# Altium schematic sheet MCU.SchDoc
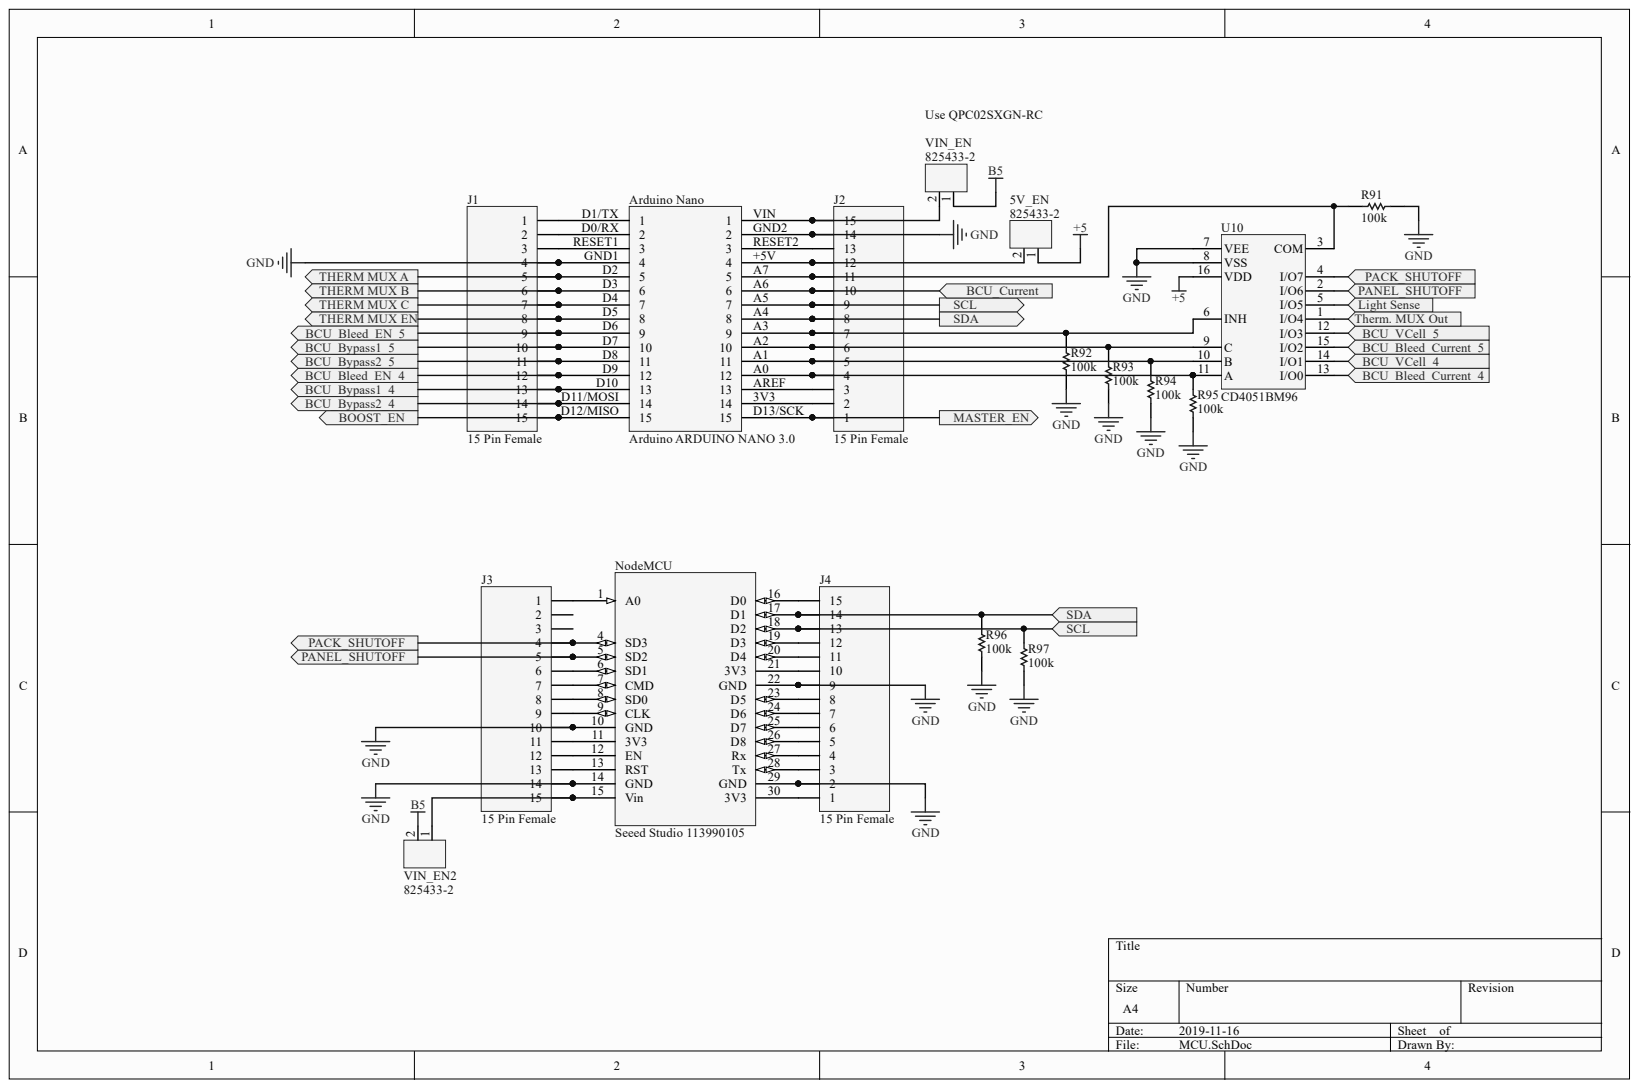
[diagram p0: MCU.SchDoc]

## Parts
5V_EN  825433-2  [PCB-teefjorx8bgtwsktknkz-1]
Arduino_Nano  Arduino ARDUINO NANO 3.0  [PCBComponent_1 - duplicate]
J1  15 Pin Female  [PCB-7wma3x43806y60wj0s74-1]
J2  15 Pin Female  [PCB-7wma3x43806y60wj0s74-1]
J3  15 Pin Female  [PCB-7wma3x43806y60wj0s74-1]
J4  15 Pin Female  [PCB-7wma3x43806y60wj0s74-1]
NodeMCU  Seeed Studio 113990105  Manufacturer Part Number: 113990105  [PCB-4f2jj0tqcwafqtaaud9f-1]
R91  100k  [PCB-8m9rktjyp1k274dv6t06-1]
R92  100k  [PCB-8m9rktjyp1k274dv6t06-1]
R93  100k  [PCB-8m9rktjyp1k274dv6t06-1]
R94  100k  [PCB-8m9rktjyp1k274dv6t06-1]
R95  100k  [PCB-8m9rktjyp1k274dv6t06-1]
R96  100k  [PCB-8m9rktjyp1k274dv6t06-1]
R97  100k  [PCB-8m9rktjyp1k274dv6t06-1]
U10  CD4051BM96  [PCB-pn8iw3pjeggaqok4u95x-1]
VIN_EN  825433-2  [PCB-teefjorx8bgtwsktknkz-1]
VIN_EN2  825433-2  [PCB-teefjorx8bgtwsktknkz-1]

## Ports
BCU_BLEED_CURRENT_4, BCU_BLEED_CURRENT_5, BCU_BLEED_EN_4, BCU_BLEED_EN_5, BCU_BYPASS1_4, BCU_BYPASS1_5, BCU_BYPASS2_4, BCU_BYPASS2_5, BCU_CURRENT, BCU_VCELL_4, BCU_VCELL_5, BOOST_EN, LIGHT SENSE, MASTER_EN, PACK_SHUTOFF, PANEL_SHUTOFF, SCL, SDA, THERM MUX A, THERM MUX B, THERM MUX C, THERM MUX EN, THERM. MUX OUT

## Nets
+5: 5V_EN.1 U10.16(VDD)
B5: VIN_EN.1 VIN_EN2.2
GND: Arduino_Nano.GND1 Arduino_Nano.GND2 J1.4 J2.14 J3.10 J3.14 J4.2 J4.9 NodeMCU.10(GND) NodeMCU.14(GND) NodeMCU.22(GND) NodeMCU.29(GND) R91.1 R92.1 R93.1 R94.1 R95.1 R96.1 R97.1 U10.7(VEE) U10.8(VSS)
BOOST_EN: Arduino_Nano.D12/MISO J1.15 ; port BOOST_EN
MASTER_EN: Arduino_Nano.D13/SCK J2.1 ; port MASTER_EN
PACK_SHUTOFF: J3.4 NodeMCU.4(SD3) U10.4(I/O7) ; port PACK_SHUTOFF
PANEL_SHUTOFF: J3.5 NodeMCU.5(SD2) U10.2(I/O6) ; port PANEL_SHUTOFF
SCL: Arduino_Nano.A5 J2.9 J4.13 NodeMCU.18(D2) R97.2 ; port SCL
SDA: Arduino_Nano.A4 J2.8 J4.14 NodeMCU.17(D1) R96.2 ; port SDA
THERM MUX A: Arduino_Nano.D2 J1.5 ; port THERM MUX A
THERM MUX B: Arduino_Nano.D3 J1.6 ; port THERM MUX B
THERM MUX C: Arduino_Nano.D4 J1.7 ; port THERM MUX C
THERM MUX EN: Arduino_Nano.D5 J1.8 ; port THERM MUX EN
Net5V_EN_2: 5V_EN.2 Arduino_Nano.+5V J2.12
NetArduino Nano_3V3: Arduino_Nano.3V3 J2.2
NetArduino Nano_A0: Arduino_Nano.A0 J2.4 R95.2 U10.11(A)
NetArduino Nano_A1: Arduino_Nano.A1 J2.5 R94.2 U10.10(B)
NetArduino Nano_A2: Arduino_Nano.A2 J2.6 R93.2 U10.9(C)
NetArduino Nano_A3: Arduino_Nano.A3 J2.7 R92.2 U10.6(INH)
NetArduino Nano_A6: Arduino_Nano.A6 J2.10 ; port BCU_CURRENT
NetArduino Nano_A7: Arduino_Nano.A7 J2.11 R91.2 U10.3(COM)
NetArduino Nano_AREF: Arduino_Nano.AREF J2.3
NetArduino Nano_D0/RX: Arduino_Nano.D0/RX J1.2
NetArduino Nano_D1/TX: Arduino_Nano.D1/TX J1.1
NetArduino Nano_D6: Arduino_Nano.D6 J1.9 ; port BCU_BLEED_EN_5
NetArduino Nano_D7: Arduino_Nano.D7 J1.10 ; port BCU_BYPASS1_5
NetArduino Nano_D8: Arduino_Nano.D8 J1.11 ; port BCU_BYPASS2_5
NetArduino Nano_D9: Arduino_Nano.D9 J1.12 ; port BCU_BLEED_EN_4
NetArduino Nano_D10: Arduino_Nano.D10 J1.13 ; port BCU_BYPASS1_4
NetArduino Nano_D11/MOSI: Arduino_Nano.D11/MOSI J1.14 ; port BCU_BYPASS2_4
NetArduino Nano_RESET1: Arduino_Nano.RESET1 J1.3
NetArduino Nano_RESET2: Arduino_Nano.RESET2 J2.13
NetArduino Nano_VIN: Arduino_Nano.VIN J2.15 VIN_EN.2
NetJ3_1: J3.1 NodeMCU.1(A0)
NetJ3_6: J3.6 NodeMCU.6(SD1)
NetJ3_7: J3.7 NodeMCU.7(CMD)
NetJ3_8: J3.8 NodeMCU.8(SD0)
NetJ3_9: J3.9 NodeMCU.9(CLK)
NetJ3_11: J3.11 NodeMCU.11(3V3)
NetJ3_12: J3.12 NodeMCU.12(EN)
NetJ3_13: J3.13 NodeMCU.13(RST)
NetJ3_15: J3.15 NodeMCU.15(Vin) VIN_EN2.1
NetJ4_1: J4.1 NodeMCU.30(3V3)
NetJ4_3: J4.3 NodeMCU.28(Tx)
NetJ4_4: J4.4 NodeMCU.27(Rx)
NetJ4_5: J4.5 NodeMCU.26(D8)
NetJ4_6: J4.6 NodeMCU.25(D7)
NetJ4_7: J4.7 NodeMCU.24(D6)
NetJ4_8: J4.8 NodeMCU.23(D5)
NetJ4_10: J4.10 NodeMCU.21(3V3)
NetJ4_11: J4.11 NodeMCU.20(D4)
NetJ4_12: J4.12 NodeMCU.19(D3)
NetJ4_15: J4.15 NodeMCU.16(D0)
NetU10_1: U10.1(I/O4) ; port THERM. MUX OUT
NetU10_5: U10.5(I/O5) ; port LIGHT SENSE
NetU10_12: U10.12(I/O3) ; port BCU_VCELL_5
NetU10_13: U10.13(I/O0) ; port BCU_BLEED_CURRENT_4
NetU10_14: U10.14(I/O1) ; port BCU_VCELL_4
NetU10_15: U10.15(I/O2) ; port BCU_BLEED_CURRENT_5

Not connected: J3 2 3

## Library data of the parts
5V_EN VIN_EN VIN_EN2 = CMP-da2d1303880405ea-18: Conn Unshrouded Header HDR 2 POS 2.54mm Solder ST Thru-Hole Automotive Box; Current Rating: 3.00 A; ELV Compliant: Compliant; Gender: Male; Lead-Free Status: Lead Free; Number of Contacts: 2; Color: Green; Component Kind: Standard; Contact Material: Phosphor Bronze; Contact Plating: Gold over Nickel, Tin, Gold, Tin over Nickel; Flammability Rating: UL 94 V-0; Housing Color: Green; Housing Material: Polyester; Library Name: FavoritesLibrary; Lifecycle Status: Active; Manufacturer Part Number: 825433-2; Mounting Style: PCB; OctopartID: f5dc3ea67f052a1b; Octopart URL: https://octopart.com/825433-2-te+connectivity-40939455; Operating Temperature: -65.0 °C to 105 °C; Orientation: Straight; Packaging: Box; Pin Pitch: 2.54 mm; REACH SVHC Compliance: No SVHC; RoHS: Compliant
Arduino_Nano = ARDUINO NANO 3.0: 3D Model Source: 3DContentCentral; Lead-Free Status: Lead Free; Component Kind: Standard; Description: Arduino Nano 3.0; Library Name: Octopart library; Manufacturer Part Number: ARDUINO NANO 3.0; OctopartID: 1f01d29ed047c0c6; Octopart URL: https://octopart.com/arduino+nano+3.0-arduino-76933664
J1-J4 = 22-28-4155: Header Connector, PCB Mount, RECEPT, 15 Contacts, PIN, 0.1 Pitch, PC TAIL Terminal; Glow Wire Compliant: Non-Compliant; Number of Circuits: 15; Component Kind: Standard; Contact Material: Phosphor Bronze; Contact Plating: Gold; Housing Color: Black; Library Name: Octopart library; Lifecycle Status: Active; Number of Positions: 15; Number of Rows: 1; Operating Temperature: 75.0 °C (max); RoHS: Compliant; Size-Length: 38.1 mm
NodeMCU = Seeed Studio 113990105-2: Nodemcu V2 Lua Based ESP8266 Dev; Component Kind: Standard; Library Name: FavoritesLibrary; OctopartID: 46578cb04ca89990; Octopart URL: https://octopart.com/113990105-seeed+studio-65875865
R91-R97 = CRGCQ0603J100K: Res, Auto, Thick Film, 100K, 5%, 0603; Component Kind: Standard; Library Name: Octopart library; Lifecycle Status: Not Listed by Manufacturer
U10 = CMP-3ce3f5ee2d39e324-4: Multiplexer IC Operating temperature: -55...+125 °C Series: CD4051 Package: SOIC-16; Bandwidth: 20.0 MHz; Lead-Free Status: Lead Free; Number of Bits: 8; Polarity: Non-Inverting; Propagation Delay Max (tpd): 20.0 ns; Quiescent Current: 80.0 nA; Supply Current: 100 µA; Supply Voltage (DC): 3.00 V (min), 20.0 V (max); Voltage Nodes: 10.0 V, 5.00 V, 15.0 V; Case/Package: PDIP, SOIC; Component Kind: Standard; Library Name: FavoritesLibrary; Lifecycle Status: Active; Manufacturer Part Number: CD4051BM96; Mounting Style: Surface Mount; Number of Channels: 8; Number of Pins: 16; OctopartID: 3ce3f5ee2d39e324; Octopart URL: https://octopart.com/cd4051bm96-texas+instruments-472252; Operating Temperature: 125 °C (max); Packaging: Cut Tape (CT), Reel, Tape & Reel (TR); REACH SVHC Compliance: No SVHC; RoHS: Compliant
